# Altium schematic sheet 3.3V Power Supply.SchDoc
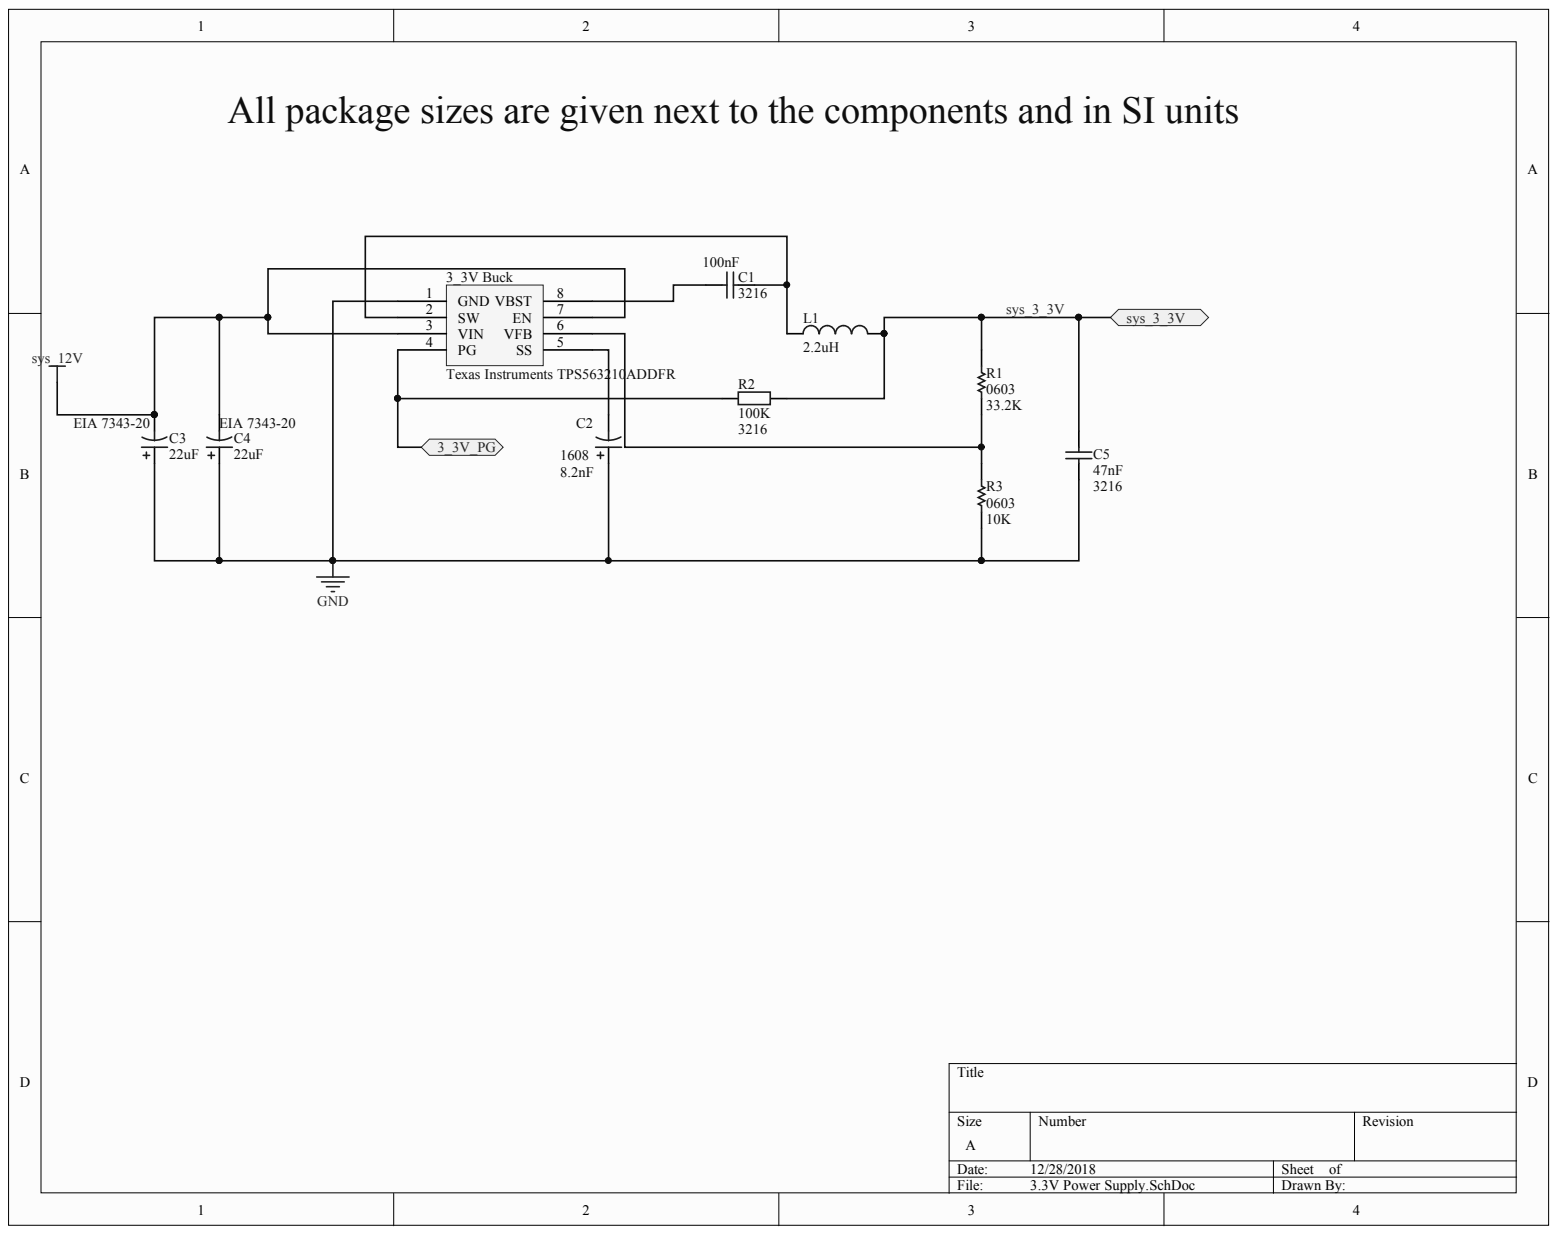
[diagram p0: 3.3V Power Supply.SchDoc]

## Parts
3_3V_Buck  Texas Instruments TPS563210ADDFR  [SOP8]
C1  Capacitance: 100nF  Case/Package: 3216  [CAPC3216X100X50ML20T25]  (hidden comment: Kemet C1206F224K1RACTU)
C2  Capacitance: 8.2nF  Case/Package: 1608  [PCB-asfpcoqvmjw65b2ui7gq-1]  (hidden comment: Cap Pol2)
C3  Capacitance: 22uF  [PCB-eb8oz4krqzk9vae1q675-1]  (hidden comment: Cap Pol2)
C4  Capacitance: 22uF  Package/case: EIA 7343-20  [PCB-eb8oz4krqzk9vae1q675-1]  (hidden comment: Cap Pol2)
C5  Capacitance: 47nF  Case/Package: 3216  [CAPC3216X100X50ML20T25]  (hidden comment: Kemet C1206F224K1RACTU)
L1  2.2uH  [INDC3225X12N]
R1  Case/Package (SI): 0603  Resistance: 33.2K  [RESC0603X28X15ML05T05]  (hidden comment: Vishay CRCW02010000Z0ED)
R2  Case/Package: 3216  Resistance: 100K  [CAPC3216X94X50ML20T25]  (hidden comment: Welwyn LRMAM1206-R02FT5)
R3  Case/Package (SI): 0603  Resistance: 10K  [RESC0603X28X15ML05T05]  (hidden comment: Vishay CRCW02010000Z0ED)

## Ports
3_3V_PG, SYS_3_3V

## Nets
GND: 3_3V_Buck.1(GND) C2.1 C3.1 C4.1 C5.1 R3.1
SYS_12V: 3_3V_Buck.3(VIN) 3_3V_Buck.7(EN) C3.2 C4.2
3_3V_PG: 3_3V_Buck.4(PG) R2.2 ; port 3_3V_PG
SYS_3_3V: C5.2 L1.2 R1.2 R2.1 ; port SYS_3_3V
Net3_3V Buck_2: 3_3V_Buck.2(SW) C1.2 L1.1
Net3_3V Buck_5: 3_3V_Buck.5(SS) C2.2
Net3_3V Buck_6: 3_3V_Buck.6(VFB) R1.1 R3.2
Net3_3V Buck_8: 3_3V_Buck.8(VBST) C1.1

## Library data of the parts
3_3V_Buck = CMP-bc7671e9543263b1-2: Conv DC-DC 4.5V to 17V Synchronous Step Down Single-Out 0.76V to 7V 3A 8-Pin SOT-23 T/R; Component Kind: Standard; Library Name: CommunityVault
C1 C5 = CMP-158244-2: Multilayer Ceramic Capacitors MLCC - SMD/SMT 100volts 0.22uF X7R 10%; Lead-Free Status: R; Voltage Rating (DC): 100.0 V; Capacitance Tolerance: ±10%; Component Kind: Standard; Dielectric Characteristic: X7R; Dielectric Material: Ceramic; Library Name: CommunityVault; Manufacturer Part Number: C1206F224K1RACTU; Mounting Style: Surface Mount; OctopartID: f3193b1bdd422cde; Octopart Url: https://octopart.com/c1206f224k1ractu-kemet-17304381; Packaging: Cut Tape (CT); REACH SVHC Compliance: No SVHC; RoHS: Compliant
C2 = CMP-12914703-5: Cap Tant Solid 2.2uF 10V M CASE 20% (1.6 X 0.85 X 0.8mm) SMD 1608 0.5 Ohm 105Â°C T/R; Equivalent Series Resistance (ESR): 500 mΩ; Voltage Rating (DC): 10.0 V; Capacitance Tolerance: ±20%; Component Kind: Standard; Library Name: CommunityVault; Mounting Style: Surface Mount; OctopartID: 025bca9e5b4bdd9c; Octopart URL: http://octopart.com/f381a225mma-avx-30375030; Packaging: Tape & Reel (TR), Cut Tape (CT); REACH SVHC Compliance: No SVHC; RoHS: Compliant
C3 C4 = CMP-eab323bbf0fa3574-2: Cap Tant Organic 330uF 2.5VDC V CASE 20% (7.3 X 4.3 X 1.8mm) SMD 7343-19 0.025 Ohm 105C T/R; Voltage Rating (DC): 2.50 V; Component Kind: Standard; Library Name: CommunityVault; Packaging: Tape & Reel (TR), Cut Tape (CT); Size-Height: 2.00 mm
  C3: Package/case: EIA 7343-20
L1 = CMP-f2de3fe83ba42d19-1: Inductor, Shielded, 2.2uh, 3.5a, 1210; Manufacturer Part Number: 74479299222; Component Kind: Standard; Library Name: CommunityVault; OctopartID: f2de3fe83ba42d19
R1 R3 = CMP-1859241-2: RES SMD 0.0 OHM JUMPER 1/20W; Lead-Free Status: Lead Free; Power Rating: 0.05 W; Resistance Tolerance: ±5%; Voltage Rating (DC): 30.0 V; Case/Package: 0201; Component Kind: Standard; Library Name: CommunityVault; Manufacturer Part Number: CRCW02010000Z0ED; Mounting Style: Surface Mount; Number of Pins: 2; OctopartID: 5e2b95433f4781ec; Octopart Url: https://octopart.com/crcw02010000z0ed-vishay-39994378; REACH SVHC Compliance: No SVHC; RoHS: Compliant; Size-Height: 0.00023 m; Size-Length: 0.0006 m; Size-Width: 0.0003 m
R2 = CMP-c1220a8799fa1ee5-1: Product Range: AEC-Q200 LRMA Series; Power Rating: 1.00 W; Resistance Tolerance: ±1%; Component Kind: Standard; Library Name: CommunityVault; Manufacturer Part Number: LRMAM1206-R02FT5; Mounting Style: Surface Mount; OctopartID: c1220a8799fa1ee5; Octopart URL: http://octopart.com/lrmam1206-r02ft5-welwyn-45055422; Packaging: Cut Tape (CT); REACH SVHC Compliance: No SVHC; RoHS: Compliant
